# Altium schematic sheet motor.SchDoc
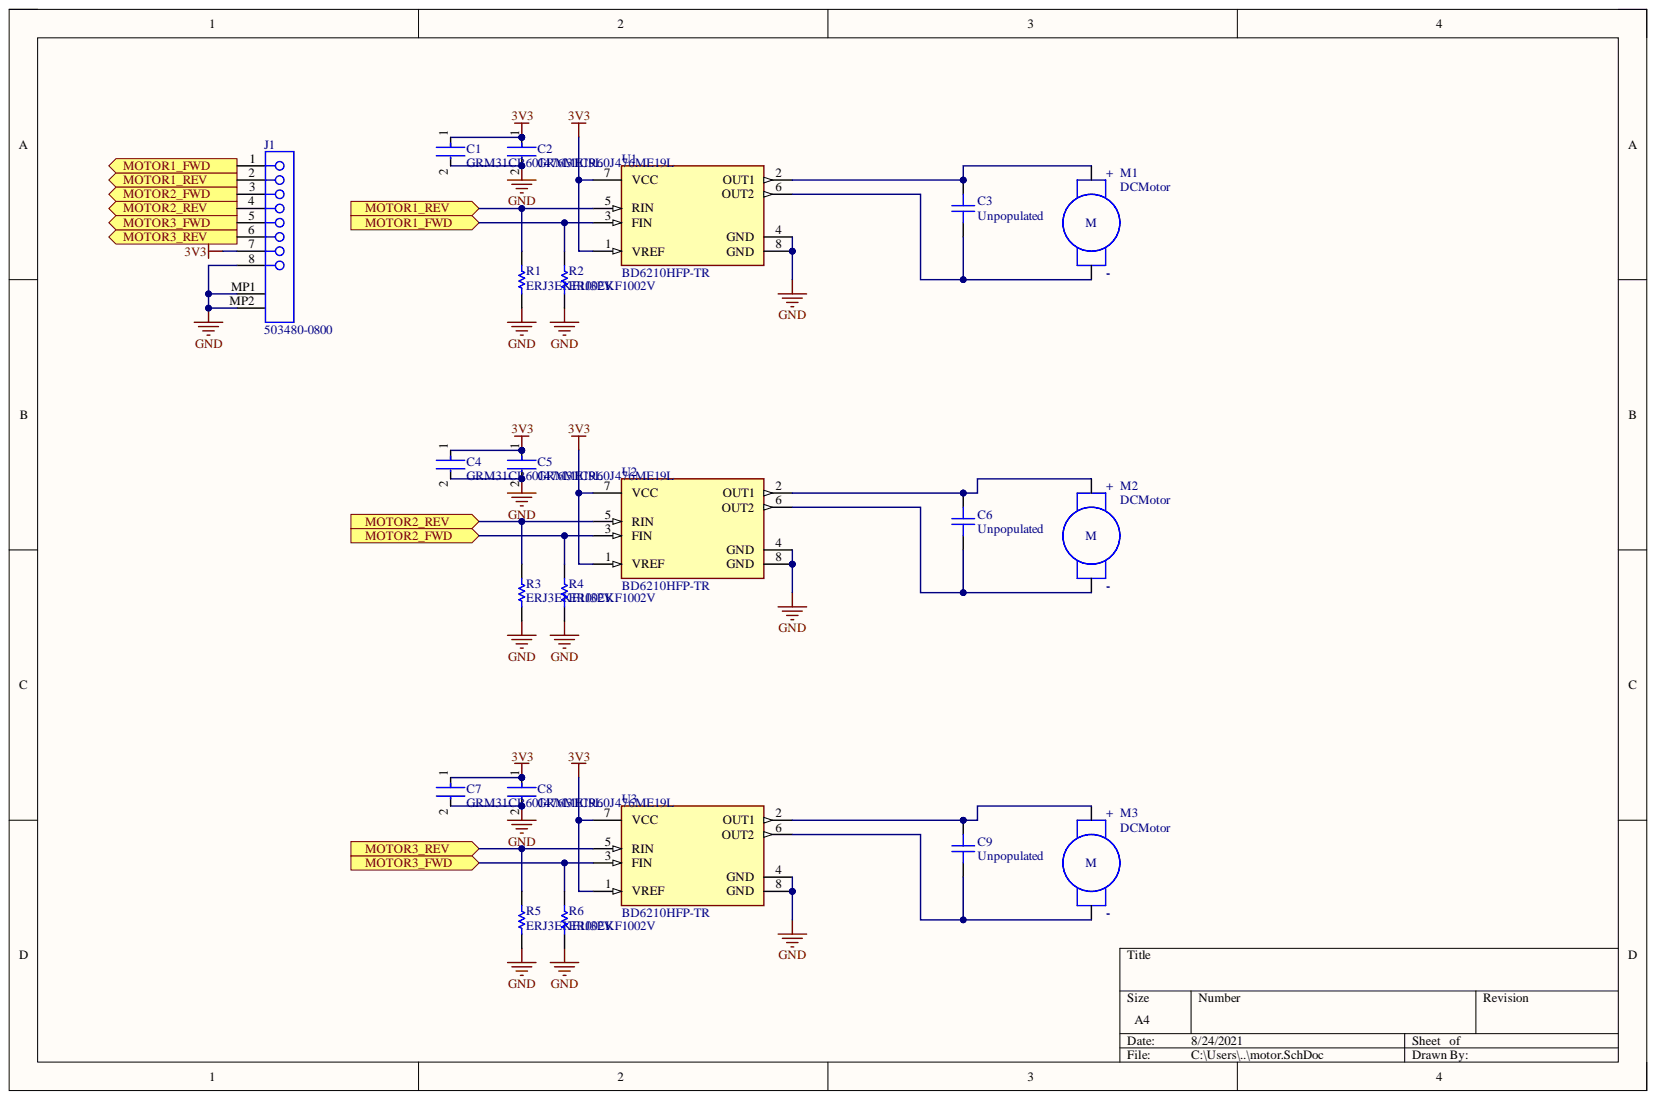
[diagram p0: motor.SchDoc]

## Parts
C1  GRM31CR60J476ME19L  [FP-GRM31C-0_2-e0_3_0_8-IPC_C]
C2  GRM31CR60J476ME19L  [FP-GRM31C-0_2-e0_3_0_8-IPC_C]
C3  Unpopulated  [2012[0805]_M]
C4  GRM31CR60J476ME19L  [FP-GRM31C-0_2-e0_3_0_8-IPC_C]
C5  GRM31CR60J476ME19L  [FP-GRM31C-0_2-e0_3_0_8-IPC_C]
C6  Unpopulated  [2012[0805]_M]
C7  GRM31CR60J476ME19L  [FP-GRM31C-0_2-e0_3_0_8-IPC_C]
C8  GRM31CR60J476ME19L  [FP-GRM31C-0_2-e0_3_0_8-IPC_C]
C9  Unpopulated  [2012[0805]_M]
J1  503480-0800  [MOLX-503480-0800]
M1  DCMotor  [CABLE-TERMINAL1x2]
M2  DCMotor  [CABLE-TERMINAL1x2]
M3  DCMotor  [CABLE-TERMINAL1x2]
R1  ERJ3EKF1002V  [RESC1609X55X30ML15T15]
R2  ERJ3EKF1002V  [RESC1609X55X30ML15T15]
R3  ERJ3EKF1002V  [RESC1609X55X30ML15T15]
R4  ERJ3EKF1002V  [RESC1609X55X30ML15T15]
R5  ERJ3EKF1002V  [RESC1609X55X30ML15T15]
R6  ERJ3EKF1002V  [RESC1609X55X30ML15T15]
U1  BD6210HFP-TR  [FP-HRP7-MFG]
U2  BD6210HFP-TR  [FP-HRP7-MFG]
U3  BD6210HFP-TR  [FP-HRP7-MFG]

## Ports
MOTOR1_FWD, MOTOR1_REV, MOTOR2_FWD, MOTOR2_REV, MOTOR3_FWD, MOTOR3_REV

## Nets
3V3: C1.1 C2.1 C4.1 C5.1 C7.1 C8.1 J1.7 U1.1(VREF) U1.7(VCC) U2.1(VREF) U2.7(VCC) U3.1(VREF) U3.7(VCC)
GND: C1.2 C2.2 C4.2 C5.2 C7.2 C8.2 J1.8 J1.MP1 J1.MP2 R1.1 R2.1 R3.1 R4.1 R5.1 R6.1 U1.4(GND) U1.8(GND) U2.4(GND) U2.8(GND) U3.4(GND) U3.8(GND)
MOTOR1_FWD: J1.1 R2.2 U1.3(FIN) ; port MOTOR1_FWD
MOTOR1_REV: J1.2 R1.2 U1.5(RIN) ; port MOTOR1_REV
MOTOR2_FWD: J1.3 R4.2 U2.3(FIN) ; port MOTOR2_FWD
MOTOR2_REV: J1.4 R3.2 U2.5(RIN) ; port MOTOR2_REV
MOTOR3_FWD: J1.5 R6.2 U3.3(FIN) ; port MOTOR3_FWD
MOTOR3_REV: J1.6 R5.2 U3.5(RIN) ; port MOTOR3_REV
NetC3_1: C3.1 M1.- U1.6(OUT2)
NetC3_2: C3.2 M1.+ U1.2(OUT1)
NetC6_1: C6.1 M2.- U2.6(OUT2)
NetC6_2: C6.2 M2.+ U2.2(OUT1)
NetC9_1: C9.1 M3.- U3.6(OUT2)
NetC9_2: C9.2 M3.+ U3.2(OUT1)

## Library data of the parts
C1 C2 C4 C5 C7 C8 = CMP-2000-06344-2: Chip Multilayer Ceramic Capacitors for General Purpose, 1206, 47uF, X5R, 15%, 20%, 6.3V; Capacitance: 47uF; Case Code (Imperial): 1206; Case Code (Metric): 3216; Case/Package: 1206; Component Kind: Standard; Depth: 1.6mm; Dielectric: X5R; Height: 1.6mm; Lead Free: Lead Free; Length: 3.2mm; Library Name: Altium Content Vault; Material: Ceramic; Max Operating Temperature: 85°C; Min Operating Temperature: -55°C; Mount: Surface Mount; Package Quantity: 2000; Packaging: Tape and Reel; Radiation Hardening: No; REACH SVHC: No SVHC; Termination: SMD/SMT; Thickness: 0.071inch; Tolerance: 20%; Voltage: 6.3V; Voltage Rating: 6.3V; Voltage Rating (DC): 6.3V; Width: 0.063inch
C3 C6 C9 = Capacitor: Generic Capacitor; Component Kind: Standard; Library Name: Passive.SchLib; Value: Unpopulated
J1 = CMP-1241-00114-1: 0.5 mm Pitch Easy-On(TM) BackFlip(TM) Type FPC Connector, 1.0 mm Mated Height, Right Angle, SMT, Dual Contact, 8 Circuits, -40 to 85 degC, ELV and RoHS Compliant, Tape and Reel; Manufacturer: Molex; Circuits (Loaded): 8; Current (Max per Contact): 0.5A; Durability (mating cycles max): 10; Entry Angle: 90degrees Angle; Mated Height: 1.00mm; Material - Metal: Phosphor Bronze; Material - Plating Mating: Gold; Material - Plating Termination: Gold, Tin; PCB Locator: No; PCB Retention: Yes; Pitch - Mating Interface: 0.50mm; Voltage (Max): 50V AC (RMS)/DC; Component Kind: Standard; ComponentLink1Description: Manufacturer URL; ComponentLink1URL: http://www.molex.com/molex/index.jsp; ComponentLink2Description: Datasheet; ComponentLink2URL: http://www.molex.com/webdocs/datasheets/pdf/en-us/5034800800_FFC_FPC_CONNECTORS.pdf; ComponentLink3Description: Package Specification; ComponentLink3URL: http://www.molex.com/pdm_docs/sd/5034800800_sd.pdf; Contact Position: Dual; Library Name: Altium Content Vault; Mounting Technology: Surface Mount; Number of Rows: 1; Orientation: Right Angle; PackageDescription: 8-Lead FPC Connector, Pitch 0.5 mm; PackageReference: 503480-0800; PackageVersion: Rev. F, 09/2012; Packing: Tape and Reel; PartNumber: 503480-0800; Polarized to PCB: Yes; RoHS: TRUE; Stackable: No
M1-M3 = CMP-034-000000-1: Generic DC motor; Component Kind: Standard; Design Item ID: DCMotor; Source: Motor.SchLib; Library Name: EPFL
R1-R6 = CMP-1012-00623-3: Chip Resistor, 10 KOhm, +/- 1%, 0.1 W, -55 to 155 degC, 0603 (1608 Metric), RoHS, Tape and Reel; Manufacturer: Panasonic; Case\/Package: 0603; Max Power Dissipation: 100mW; Resistance: 10KOhm; Temperature Coefficient: 100ppm\/degreesC; Voltage Rating: 75V; Case Code (Imperial): 0603; Case Code (Metric): 1608; Component Kind: Standard; Composition: ThickFilm; Contact Plating: Tin; Datasheet URL: https://industrial.panasonic.com/cdbs/www-data/pdf/RDA0000/AOA0000C304.pdf; Datasheet Version: 02/2017; Depth: 0.8mm; Height: 0.45mm; Lead Free: LeadFree; Length: 1.6mm; Library Name: Altium Content Vault; Manufacturer URL: http://www.panasonic.com/; Max Operating Temperature: 155degC; Military Standard: Not; Min Operating Temperature: -55degC; Mount: SurfaceMount; Mounting Technology: SurfaceMount; Number of Pins: 2; Number of Terminations: 2; Package Description: 2-Pin Surface Mount Device, Body 1.6 x 0.85 mm; Package Quantity: 1; Package Reference: 603; Packaging: TapeandReel; Power Rating: 100mW; Radiation Hardening: No; REACH SVHC: NoSVHC; RoHSCompliant: true; Termination: SMD/SMT; Tolerance: 1%; Voltage Rating (DC): 75V; Width: 0.031inch
U1-U3 = CMP-08935-000017-1: IC MOTOR DRIVER 3V-5.5V HRP7; Interface: On/Off; Logic Function: AND GATE; Max Supply Voltage: 5.5V; Nominal Supply Current: 1.5mA; Number of Outputs: 1; Output Current: 500mA; Case/Package: TO-263-8; Component Kind: Standard; Lead Free: Lead Free; Library Name: Altium Content Vault; Max Operating Temperature: 85°C; Min Operating Temperature: -40°C; Mount: Surface Mount; Number of Pins: 8; Package Quantity: 2000; Packaging: Tape and Reel; Radiation Hardening: No; RoHS Compliant: Yes; Supply Current: 1.5mA
